# Altium schematic sheet ShieldFPGA.SchDoc
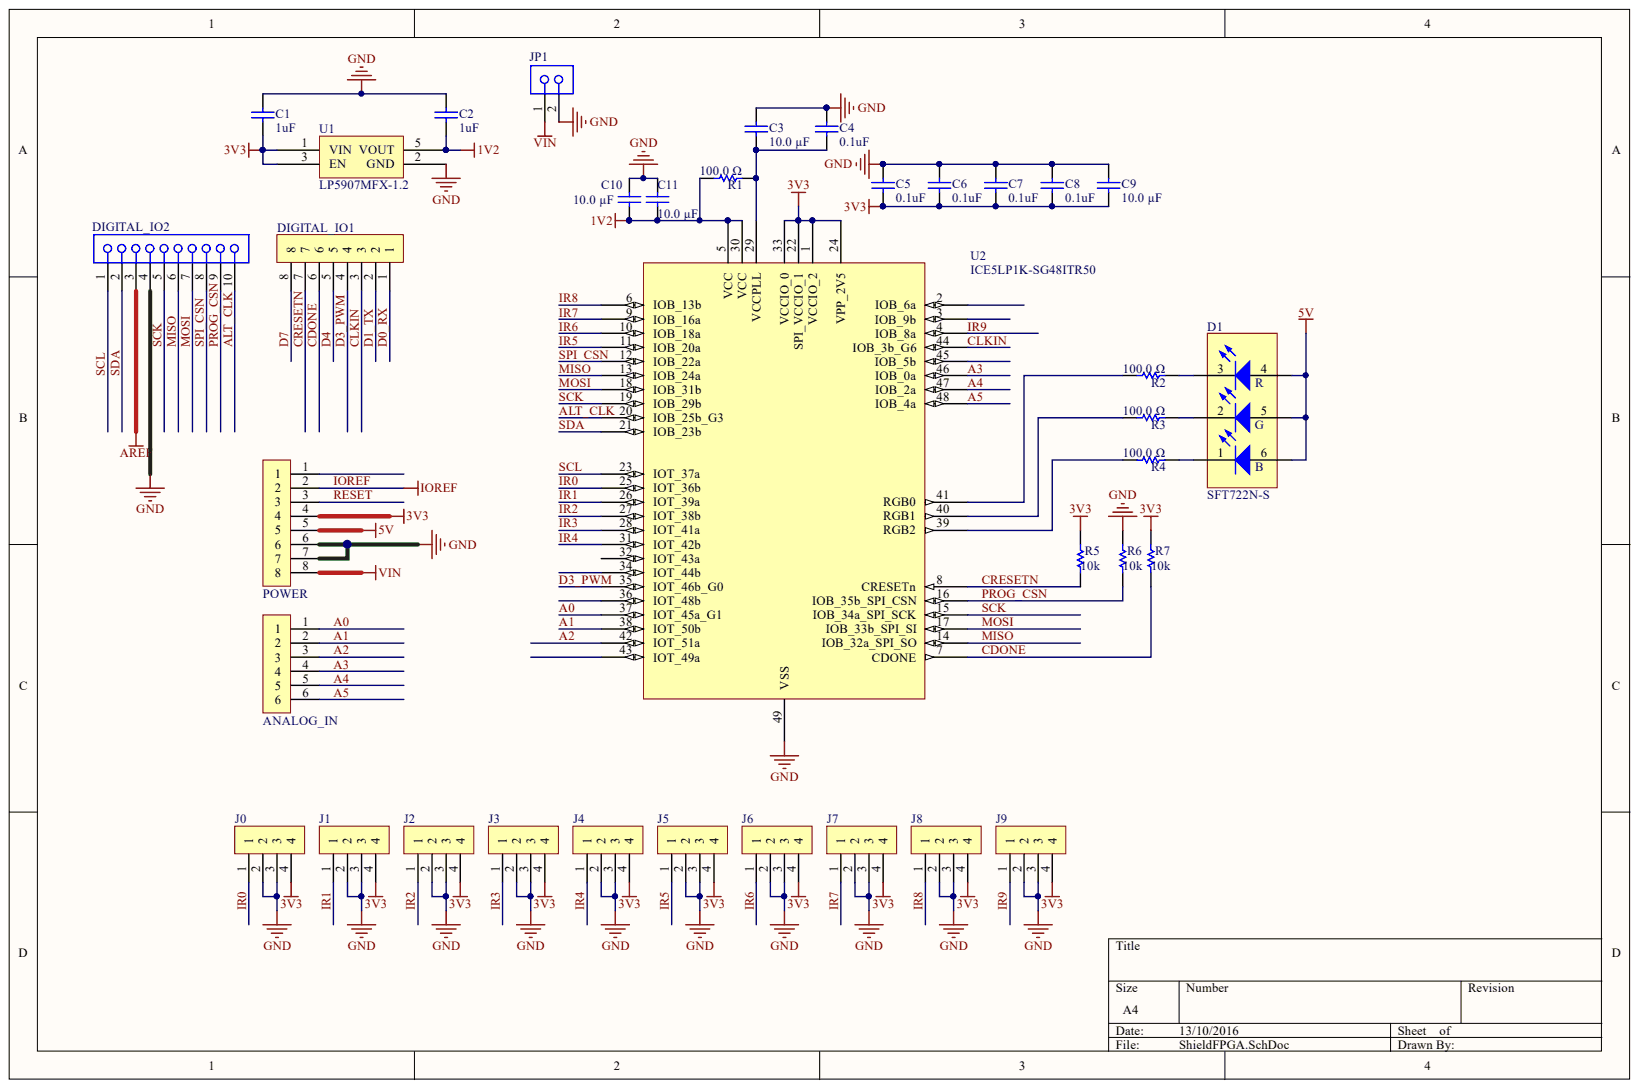
[diagram p0: ShieldFPGA.SchDoc]

## Parts
ANALOG_IN  Samtec SSW-106-03-T-S  [PCB-u2jst1hxsnkrtma0vk8f-1]  (value not drawn)
C1  Capacitance: 1uF  [CC0805ZRY5V7BB105]  (hidden comment: Yageo CC0805ZRY5V7BB105)
C2  Capacitance: 1uF  [CC0805ZRY5V7BB105]  (hidden comment: Yageo CC0805ZRY5V7BB105)
C3  Murata GRM21BR60J106ME19L  [PCB-kvlayxhdasikqiwrnrry-1]  (value not drawn)
C4  Capacitance: 0.1uF  [PCB-4097432-1]  (hidden comment: Murata GRM21BR71E104KA01L)
C5  Capacitance: 0.1uF  [PCB-4097432-1]  (hidden comment: Murata GRM21BR71E104KA01L)
C6  Capacitance: 0.1uF  [PCB-4097432-1]  (hidden comment: Murata GRM21BR71E104KA01L)
C7  Capacitance: 0.1uF  [PCB-4097432-1]  (hidden comment: Murata GRM21BR71E104KA01L)
C8  Capacitance: 0.1uF  [PCB-4097432-1]  (hidden comment: Murata GRM21BR71E104KA01L)
C9  Murata GRM21BR60J106ME19L  [PCB-kvlayxhdasikqiwrnrry-1]  (value not drawn)
C10  Capacitance: 10.0 µF  [PCB-kvlayxhdasikqiwrnrry-1]  (hidden comment: Murata GRM21BR60J106ME19L)
C11  Murata GRM21BR60J106ME19L  [PCB-kvlayxhdasikqiwrnrry-1]  (value not drawn)
D1  SFT722N-S  [SOP6]
DIGITAL_IO1  Samtec SSW-108-03-T-S  [PCB-wrnbudahzo14bpf5ge4a-1]  (value not drawn)
DIGITAL_IO2  SSW-110-03-T-S  [SMTC-SSW-110-03-X-S]  (value not drawn)
J0  Samtec SSW-104-03-G-S  [PCB-uvjp1o91d879wf416sg6-1]  (value not drawn)
J1  Samtec SSW-104-03-G-S  [PCB-uvjp1o91d879wf416sg6-1]  (value not drawn)
J2  Samtec SSW-104-03-G-S  [PCB-uvjp1o91d879wf416sg6-1]  (value not drawn)
J3  Samtec SSW-104-03-G-S  [PCB-uvjp1o91d879wf416sg6-1]  (value not drawn)
J4  Samtec SSW-104-03-G-S  [PCB-uvjp1o91d879wf416sg6-1]  (value not drawn)
J5  Samtec SSW-104-03-G-S  [PCB-uvjp1o91d879wf416sg6-1]  (value not drawn)
J6  Samtec SSW-104-03-G-S  [PCB-uvjp1o91d879wf416sg6-1]  (value not drawn)
J7  Samtec SSW-104-03-G-S  [PCB-uvjp1o91d879wf416sg6-1]  (value not drawn)
J8  Samtec SSW-104-03-G-S  [PCB-uvjp1o91d879wf416sg6-1]  (value not drawn)
J9  Samtec SSW-104-03-G-S  [PCB-uvjp1o91d879wf416sg6-1]  (value not drawn)
JP1  SSW-102-02-G-S  [SMTC-SSW-102-02-X-S]  (value not drawn)
POWER  Samtec SSW-108-03-T-S  [PCB-wrnbudahzo14bpf5ge4a-1]  (value not drawn)
R1  Resistance: 100.0 Ω  [R0805_B]  (hidden comment: YAGEO RC0805JR-07100RL)
R2  Resistance: 100.0 Ω  [R0805_B]  (hidden comment: YAGEO RC0805JR-07100RL)
R3  Resistance: 100.0 Ω  [R0805_B]  (hidden comment: YAGEO RC0805JR-07100RL)
R4  Resistance: 100.0 Ω  [R0805_B]  (hidden comment: YAGEO RC0805JR-07100RL)
R5  Resistance: 10k  [PCB-6qrry2s7daabm3r7rzwy-1]  (hidden comment: RC0805FR-0710KL)
R6  Resistance: 10k  [PCB-6qrry2s7daabm3r7rzwy-1]  (hidden comment: RC0805FR-0710KL)
R7  Resistance: 10k  [PCB-6qrry2s7daabm3r7rzwy-1]  (hidden comment: RC0805FR-0710KL)
U1  LP5907MFX-1.2  [PCB-vmgnb0qsce01ik6qlkbe-1]
U2  ICE5LP1K-SG48ITR50  [PCB-zey2g5hzou0uwya4tafe-1]

## Nets
1V2: C2.1 C10.2 C11.2 R1.1 U1.5(VOUT) U2.5(VCC) U2.30(VCC)
3V3: C1.1 C5.1 C6.1 C7.1 C8.1 C9.2 J0.4 J1.4 J2.4 J3.4 J4.4 J5.4 J6.4 J7.4 J8.4 J9.4 POWER.4 R5.2 R7.2 U1.1(VIN) U1.3(EN) U2.1(VCCIO_2) U2.22(SPI_VCCIO_1) U2.24(VPP_2V5) U2.33(VCCIO_0)
5V: D1.4 D1.5 D1.6 POWER.5
AREF: DIGITAL_IO2.3
GND: C1.2 C2.2 C3.1 C4.2 C5.2 C6.2 C7.2 C8.2 C9.1 C10.1 C11.1 DIGITAL_IO2.4 J0.2 J0.3 J1.2 J1.3 J2.2 J2.3 J3.2 J3.3 J4.2 J4.3 J5.2 J5.3 J6.2 J6.3 J7.2 J7.3 J8.2 J8.3 J9.2 J9.3 JP1.2 POWER.6 POWER.7 R6.2 U1.2(GND) U2.49(VSS)
VIN: JP1.1 POWER.8
A0: ANALOG_IN.1 U2.37(IOT_45a_G1)
A1: ANALOG_IN.2 U2.38(IOT_50b)
A2: ANALOG_IN.3 U2.42(IOT_51a)
A3: ANALOG_IN.4 U2.46(IOB_0a)
A4: ANALOG_IN.5 U2.47(IOB_2a)
A5: ANALOG_IN.6 U2.48(IOB_4a)
ALT_CLK: DIGITAL_IO2.10 U2.20(IOB_25b_G3)
CDONE: DIGITAL_IO1.6 R7.1 U2.7(CDONE)
CLKIN: DIGITAL_IO1.3 U2.44(IOB_3b_G6)
CRESETN: DIGITAL_IO1.7 R5.1 U2.8(CRESETn)
D0_RX: DIGITAL_IO1.1
D1_TX: DIGITAL_IO1.2
D3_PWM: DIGITAL_IO1.4 U2.35(IOT_46b_G0)
D4: DIGITAL_IO1.5
D7: DIGITAL_IO1.8
IOREF: POWER.2
IR0: J0.1 U2.25(IOT_36b)
IR1: J1.1 U2.26(IOT_39a)
IR2: J2.1 U2.27(IOT_38b)
IR3: J3.1 U2.28(IOT_41a)
IR4: J4.1 U2.31(IOT_42b)
IR5: J5.1 U2.11(IOB_20a)
IR6: J6.1 U2.10(IOB_18a)
IR7: J7.1 U2.9(IOB_16a)
IR8: J8.1 U2.6(IOB_13b)
IR9: J9.1 U2.4(IOB_8a)
MISO: DIGITAL_IO2.6 U2.13(IOB_24a) U2.14(IOB_32a_SPI_SO)
MOSI: DIGITAL_IO2.7 U2.17(IOB_33b_SPI_SI) U2.18(IOB_31b)
PROG_CSN: DIGITAL_IO2.9 R6.1 U2.16(IOB_35b_SPI_CSN)
RESET: POWER.3
SCK: DIGITAL_IO2.5 U2.15(IOB_34a_SPI_SCK) U2.19(IOB_29b)
SCL: DIGITAL_IO2.1 U2.23(IOT_37a)
SDA: DIGITAL_IO2.2 U2.21(IOB_23b)
SPI_CSN: DIGITAL_IO2.8 U2.12(IOB_22a)
NetC3_2: C3.2 C4.1 R1.2 U2.29(VCCPLL)
NetD1_1: D1.1 R4.2
NetD1_2: D1.2 R3.2
NetD1_3: D1.3 R2.2
NetR2_1: R2.1 U2.41(RGB0)
NetR3_1: R3.1 U2.40(RGB1)
NetR4_1: R4.1 U2.39(RGB2)

Not connected: POWER 1; U2 2(IOB_6a) 3(IOB_9b) 32(IOT_43a) 34(IOT_44b) 36(IOT_48b) 43(IOT_49a) 45(IOB_5b)

## Library data of the parts
ANALOG_IN = CMP-3e54f652de64e193-1: Conn Socket Strip SKT 6 POS 2.54mm Solder ST Thru-Hole; Gender: Female; Number of Contacts: 6; Number of Mating Cycles: 100; Color: Black; Component Kind: Standard; Contact Material: Phosphor Bronze; Contact Plating: Tin; Library Name: CommunityVault; Manufacturer Part Number: SSW-106-03-T-S; Mounting Style: Through Hole; Number of Rows: 1; OctopartID: 3e54f652de64e193; Octopart URL: http://octopart.com/ssw-106-03-t-s-samtec-17614166; Pin Pitch: 2.54 mm; RoHS: Yes
C1 C2 = CMP-144164-3: CAP CER 1UF 16V Y5V 0805; Capacitance Tolerance: +80/-20%; Dielectric Material: Ceramic Multilayer; Lead-Free Status: Lead Free; Voltage Rating (DC): 16.0 V; Component Kind: Standard; Dielectric Characteristic: Y5V; Library Name: CommunityVault; Mounting Style: Surface Mount; Number of Pins: 2; OctopartID: 7115ba9bce5caed2; Octopart Url: https://octopart.com/cc0805zry5v7bb105-yageo-42244655; REACH SVHC Compliance: No SVHC; RoHS: Compliant
C3 C9 C11 = CMP-4b5b82539172949c-1: GRM Series 0805 10 uF 6.3 V X5R ±20 % SMT Monolithic Ceramic Capacitor; Capacitance: 10.0 µF; Capacitance Tolerance: ±20%; Case/Package (SI): 2012; Lead-Free Status: Lead Free; Voltage Rating (DC): 6.30 V; Case/Package: 0805; Component Kind: Standard; Dielectric Characteristic: X5R; Library Name: CommunityVault; Lifecycle Status: Active; Manufacturer Part Number: GRM21BR60J106ME19L; Mounting Style: Surface Mount; OctopartID: 4b5b82539172949c; Octopart URL: http://octopart.com/grm21br60j106me19l-murata-196431; Packaging: Cut Tape (CT), Tape & Reel (TR); RoHS: Compliant; Size-Height: 1.25 mm; Size-Length: 2.00 mm; Size-Thickness: 1.25 mm; Size-Width: 1.25 mm
C4-C8 = CMP-19299740-3: 0805 0.1 uF 25 V ±10% Tolerance X7R Surface Mount Multilayer Ceramic Capacitor; Case/Package (SI): 2012; Lead-Free Status: Lead Free; Voltage Rating (DC): 25.0 V; Capacitance Tolerance: ±10%; Case/Package: 0805, 2012; Component Kind: Standard; Dielectric Characteristic: X7R; Library Name: CommunityVault; Lifecycle Status: Active; Manufacturer Part Number: GRM21BR71E104KA01L; Mounting Style: Surface Mount; Number of Pins: 2; OctopartID: df14161c055100d3; Octopart URL: http://octopart.com/grm21br71e104ka01l-murata-196387; Packaging: Cut Tape (CT), Tape & Reel (TR); REACH SVHC Compliance: No SVHC; RoHS: Compliant; Size-Height: 0.00125 m; Size-Length: 0.002 m; Size-Thickness: 0.00125 m; Size-Width: 0.00125 m
C10 = CMP-4b5b82539172949c-1: GRM Series 0805 10 uF 6.3 V X5R ±20 % SMT Monolithic Ceramic Capacitor; Capacitance Tolerance: ±20%; Case/Package (SI): 2012; Lead-Free Status: Lead Free; Voltage Rating (DC): 6.30 V; Case/Package: 0805; Component Kind: Standard; Dielectric Characteristic: X5R; Library Name: CommunityVault; Lifecycle Status: Active; Manufacturer Part Number: GRM21BR60J106ME19L; Mounting Style: Surface Mount; OctopartID: 4b5b82539172949c; Octopart URL: http://octopart.com/grm21br60j106me19l-murata-196431; Packaging: Cut Tape (CT), Tape & Reel (TR); RoHS: Compliant; Size-Height: 1.25 mm; Size-Length: 2.00 mm; Size-Thickness: 1.25 mm; Size-Width: 1.25 mm
D1 = CMP-9b9453bd01674453-1: Conn Socket Strip SKT 72 POS 2.54mm Solder ST Thru-Hole; Component Kind: Standard; Library Name: CommunityVault; Manufacturer Part Number: SFT722N-S; OctopartID: 9b9453bd01674453; Octopart URL: http://octopart.com/sft722n-s-seoul+semiconductor-19120021
DIGITAL_IO1 POWER = CMP-dd8c158e3c8c024b-3: Conn Socket Strip SKT 8 POS 2.54mm Solder ST Thru-Hole; Number of Mating Cycles: 100; Color: Black; Component Kind: Standard; Contact Material: Phosphor Bronze; Contact Plating: Tin; Library Name: CommunityVault; Manufacturer Part Number: SSW-108-03-T-S; Mounting Style: Through Hole; Number of Rows: 1; OctopartID: dd8c158e3c8c024b; Octopart URL: http://octopart.com/ssw-108-03-t-s-samtec-17351782; Pin Pitch: 2.54 mm; RoHS: Yes
DIGITAL_IO2 = CMP-6496846-2: SQ Post Socket, Through-hole, Vertical, -55 to 105 degC, 2.54 mm Pitch, 10-Pin, Female, RoHS; Number of Mating Cycles: 100; Pin Pitch: 0.00254 m; Color: Black; Component Kind: Standard; Contact Material: Phosphor Bronze; Contact Plating: Tin; Library Name: CommunityVault; Mounting Style: Through Hole; Number of Rows: 1; OctopartID: 83784b7a08bc5cbf; Octopart Url: https://octopart.com/ssw-110-03-t-s-samtec-17346210; RoHS: Yes
J0-J9 = CMP-3861f0617aece7a6-2: Conn Socket Strip SKT 4 POS 2.54mm Solder ST Thru-Hole; Number of Mating Cycles: 100; Color: Black; Component Kind: Standard; Contact Material: Phosphor Bronze; Contact Plating: Gold; Library Name: CommunityVault; Manufacturer Part Number: SSW-104-03-G-S; Mounting Style: Through Hole; Number of Rows: 1; OctopartID: 3861f0617aece7a6; Octopart URL: http://octopart.com/ssw-104-03-g-s-samtec-17459179; Pin Pitch: 2.54 mm; RoHS: Yes
JP1 = CMP-678880-2: SQ Post Socket, Through-hole, Vertical, -55 to 125 degC, 2.54 mm Pitch, 2-Pin, Female, RoHS; Contact Resistance: 0.01 Ω; Lead-Free Status: Lead Free; Number of Contacts: 2; Number of Mating Cycles: 100; Pin Pitch: 0.00254 m; Color: Black; Component Kind: Standard; Contact Material: Phosphor Bronze; Contact Plating: Gold; Gender: Female; Housing Color: Black; Housing Material: Plastic; Library Name: CommunityVault; Mounting Style: Through Hole; Number of Positions: 2; Number of Rows: 1; OctopartID: d3c01ace73730c07; Octopart Url: https://octopart.com/ssw-102-02-g-s-samtec-296405; Packaging: Tube; REACH SVHC Compliance: No SVHC; RoHS: Yes; Size-Height: 0.00851 m; Size-Length: 0.00559 m; Size-Width: 0.00241 m
R1-R4 = CMP-5c8f97da1f6a8348-1: RC Series 0805 0.125 W 100 Ohms 5% ±100 ppm/°C SMT Thick Film Chip Resistor; Case/Package (SI): 2012; Lead-Free Status: Lead Free; Power Rating: 0.125 W; Voltage Rating (DC): 150.0 V; Case/Package: 0805; Component Kind: Standard; Library Name: CommunityVault; Manufacturer Part Number: RC0805JR-07100RL; Mounting Style: Surface Mount; Number of Pins: 2; OctopartID: 5c8f97da1f6a8348; Octopart Url: http://octopart.com/rc0805jr-07100rl-yageo-39806595; REACH SVHC Compliance: No SVHC; Resistance Tolerance: ±5%; RoHS: Compliant; Seller Landing  Url: https://octopart-clicks.com/click/track?country=US&ak=8157f166&sig=02b0f06&sid=5489&ppid=39806595&vpid=190517146&ct=offers; Size-Height: 0.0005 m; Size-Length: 0.002 m; Size-Width: 0.00125 m
R5-R7 = CMP-1854303-14: Res Thick Film 2.0 x 1.2 mm 10K Ohm 1% 0.125W(1/8W) 100ppm/ C Molded SMD Paper T/R; Case/Package (SI): 2012; Lead-Free Status: Lead Free; Power Rating: 125 mW; Voltage Rating (DC): 150 V; Case/Package: 0805; Component Kind: Standard; Library Name: CommunityVault; Manufacturer Part Number: RC0805FR-0710KL; OctopartID: d971121d0e3806b6; Octopart URL: http://octopart.com/rc0805fr-0710kl-yageo-40301103; REACH SVHC Compliance: No SVHC; RoHS: Compliant; Size-Height: 500 µm; Size-Length: 2.00 mm; Size-Width: 1.25 mm
U1 = CMP-3275fae8abfdedb2-3: 250mA, Ultra-Low Noise Low-Dropout egulator 5-SOT-23 -40 to 125; Manufacturer Part Number: LP5907MFX-1.8/NOPB; Dropout Voltage: 0.05 V; Lead-Free Status: Lead Free; Number of Regulated Outputs: 1; Output Capacitor Type: Ceramic; Output Current: 0.25 A; Quiescent Current: 1.2e-05 A; Thermal Shutdown: Yes; Component Kind: Standard; Library Name: CommunityVault; Lifecycle Status: Active; Number of Pins: 5; OctopartID: 3275fae8abfdedb2; Octopart URL: http://octopart.com/lp5907mfx-1.8%2Fnopb-texas+instruments-26721518; RoHS: Compliant
U2 = CMP-c26b0b6278be1dac-4: IC FPGA 1100LUTS 1.2V 48QFN; Component Kind: Standard; Library Name: CommunityVault; OctopartID: c26b0b6278be1dac; Octopart URL: http://octopart.com/ice5lp1k-sg48itr50-lattice+semiconductor-61605767
